# Altium schematic sheet MotorInterface.SchDoc
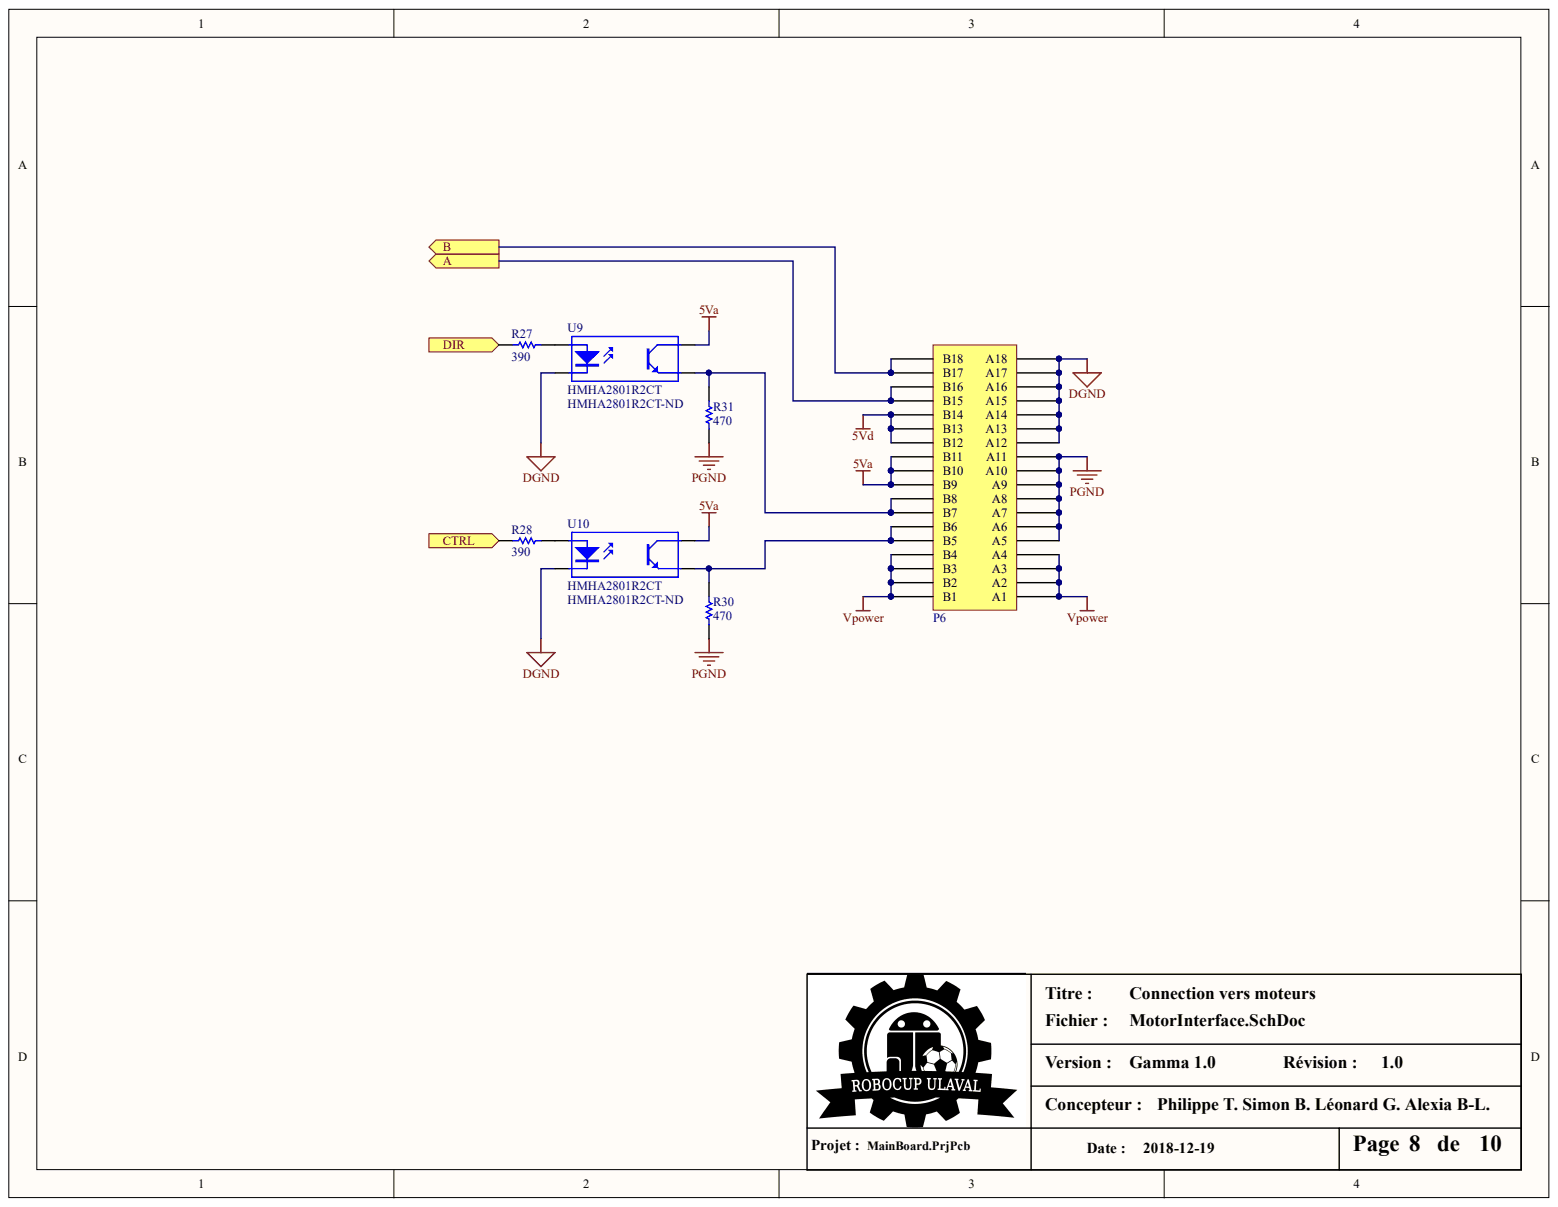
[diagram p0: MotorInterface.SchDoc]

## Parts
P6  0877159006  [0877159006]  (value not drawn)
R27  RC0603JR-07390RL  [0603]  (value not drawn)
R28  RC0603JR-07390RL  [0603]  (value not drawn)
R30  RC0603JR-07470RL  [0603]  (value not drawn)
R31  RC0603JR-07470RL  [0603]  (value not drawn)
U9  HMHA2801R2CT  Supplier Part Number 1: HMHA2801R2CT-ND  [SOIC127P700X220-4N]
U10  HMHA2801R2CT  Supplier Part Number 1: HMHA2801R2CT-ND  [SOIC127P700X220-4N]

## Ports
A, B, CTRL, DIR

## Nets
5VA: P6.B9 P6.B10 P6.B11 U9.4 U10.4
5VD: P6.B12 P6.B13 P6.B14
DGND: P6.A12 P6.A13 P6.A14 P6.A15 P6.A16 P6.A17 P6.A18 U9.2 U10.2
PGND: P6.A5 P6.A6 P6.A7 P6.A8 P6.A9 P6.A10 P6.A11 R30.1 R31.1
VPOWER: P6.A1 P6.A2 P6.A3 P6.A4 P6.B1 P6.B2 P6.B3 P6.B4
A: P6.B15 P6.B16 ; port A
B: P6.B17 P6.B18 ; port B
CTRL: R28.1 ; port CTRL
DIR: R27.1 ; port DIR
NetP6_B5: P6.B5 P6.B6 R30.2 U10.3
NetP6_B7: P6.B7 P6.B8 R31.2 U9.3
NetR27_2: R27.2 U9.1
NetR28_2: R28.2 U10.1

## Library data of the parts
P6 = 0877159006: CONN PCI EXPRESS 36POS 1MM GOLD; Component Kind: Standard; Library Name: MainBoard.SCHLIB
R27 R28 = RC0603JR-07390RL: RES SMD 390 OHM 5% 1/10W 0603; Manufacturer: Yageo; Power (Watts): 0.1W, 1/10W; Resistance (Ohms): 390; Temperature Coefficient: ±100ppm/°C; Category: Resistors; Component Kind: Standard; ComponentLink1Description: http://www.yageo.com/documents/recent/PYu-RC_Group_51_RoHS_L_04.pdf; ComponentLink1URL: http://www.yageo.com/documents/recent/PYu-RC_Group_51_RoHS_L_04.pdf; Composition: Thick Film; Features: Moisture Resistant; Height: 0.022" (0.55mm); Library Name: ResCap.SchLib; Manufacturer Part Number: RC0603JR-07390RL; Number of Terminations: 2; Operating Temperature: -55°C ~ 155°C; Package / Case: 0603 (1608 Metric); Packaging: Cut Tape (CT); Pricing 1: 1=0.15, 10=0.017, 25=0.012, 100=0.0067, 250=0.00508, 500=0.00408, 1000=0.003, 2500=0.0026 (CAD); RoHS: RoHS Compliant; Size / Dimension: 0.063" L x 0.031" W (1.60mm x 0.80mm); Stock 1: 718727; Supplier 1: Digi-Key; Supplier Device Package: 0603; Supplier Part Number 1: 311-390GRCT-ND; Tolerance: ±5%
R30 R31 = RC0603JR-07470RL: RES SMD 470 OHM 5% 1/10W 0603; Manufacturer: Yageo; Power (Watts): 0.1W, 1/10W; Resistance (Ohms): 470; Temperature Coefficient: ±100ppm/°C; Category: Resistors; Component Kind: Standard; ComponentLink1Description: http://www.yageo.com/documents/recent/PYu-RC_Group_51_RoHS_L_04.pdf; ComponentLink1URL: http://www.yageo.com/documents/recent/PYu-RC_Group_51_RoHS_L_04.pdf; Composition: Thick Film; Features: Moisture Resistant; Height: 0.022" (0.55mm); Library Name: ResCap.SchLib; Manufacturer Part Number: RC0603JR-07470RL; Number of Terminations: 2; Operating Temperature: -55°C ~ 155°C; Package / Case: 0603 (1608 Metric); Packaging: Cut Tape (CT); Pricing 1: 1=0.15, 10=0.017, 25=0.012, 100=0.0067, 250=0.00508, 500=0.00408, 1000=0.003, 2500=0.0026 (CAD); RoHS: RoHS Compliant; Size / Dimension: 0.063" L x 0.031" W (1.60mm x 0.80mm); Stock 1: 968246; Supplier 1: Digi-Key; Supplier Device Package: 0603; Supplier Part Number 1: 311-470GRCT-ND; Tolerance: ±5%
U9 U10 = HMHA2801R2CT: OPTOISOLATOR 3.75KV TRANS 4-SO; Component Kind: Standard; Library Name: MainBoard.SCHLIB; Supplier 1: Digi-Key
